# Altium schematic sheet PowerSupply.SchDoc
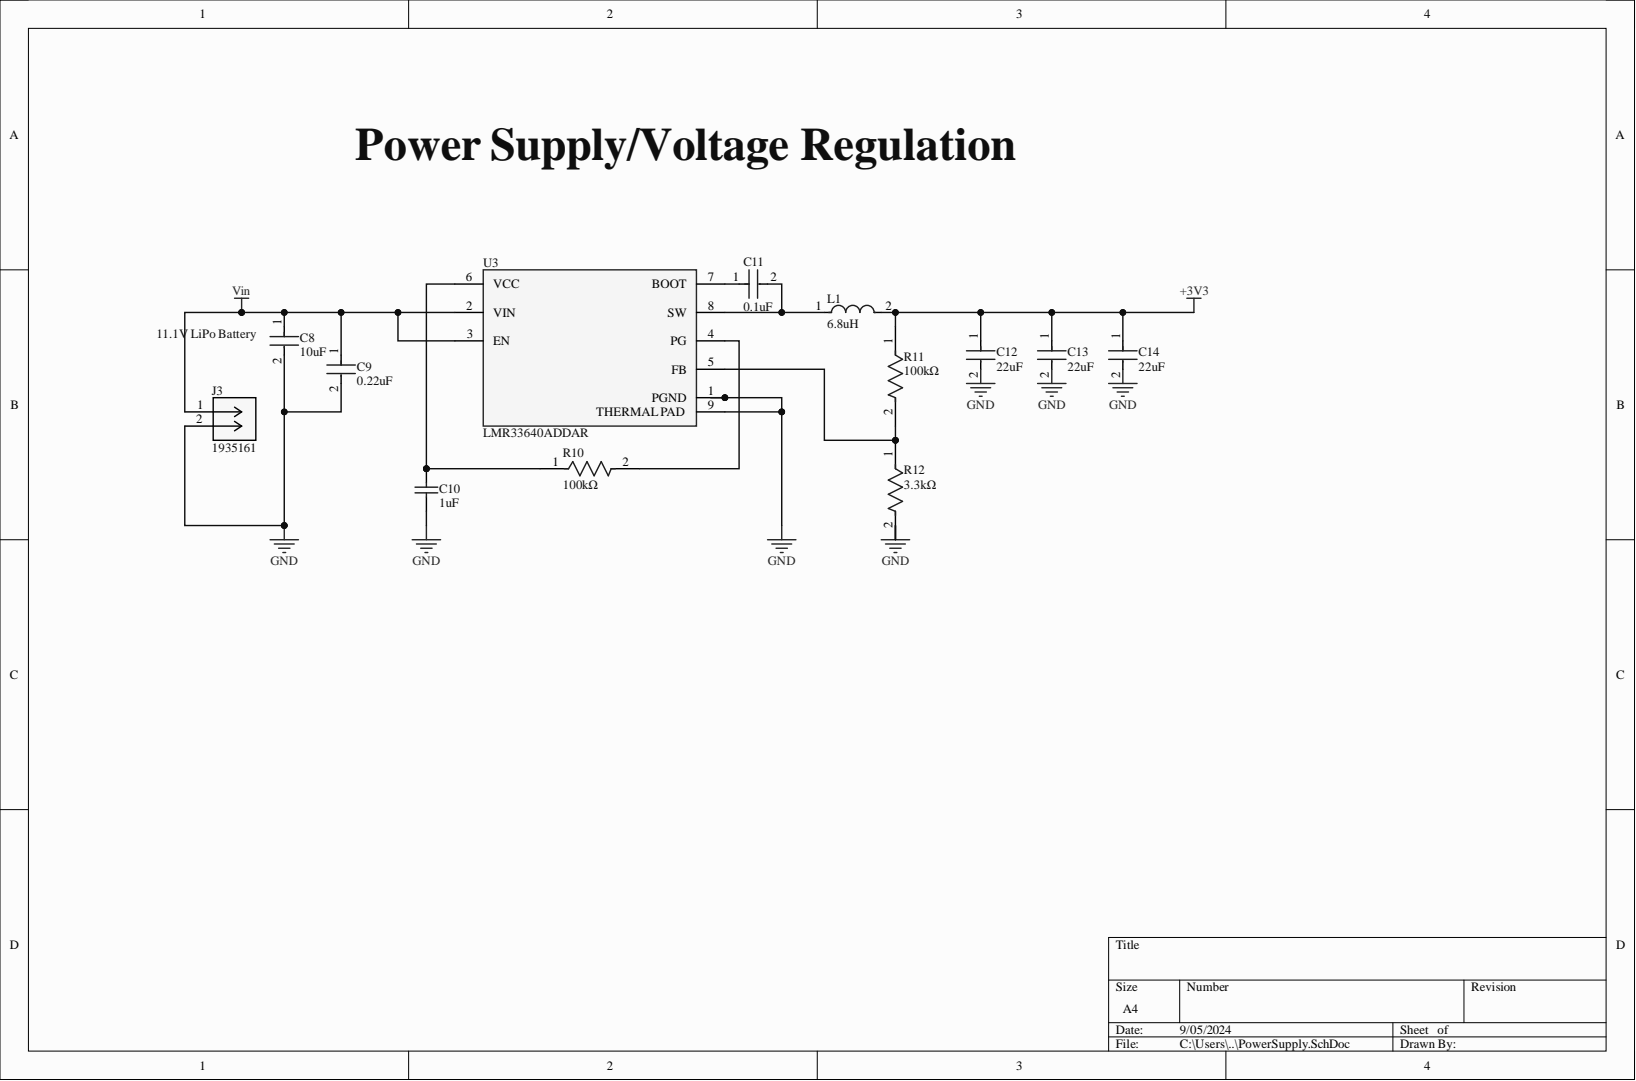
[diagram p0: PowerSupply.SchDoc]

## Parts
C8  10uF  [FP-C0805C-DG-MFG]
C9  0.22uF  [FP-C0603C-CF-MFG]
C10  1uF  [CAPC3216X180X50ML20T25]
C11  0.1uF  [FP-C0805C104M5UACTU-MFG]
C12  22uF  [FP-C3225-250-0_4-IPC_B]
C13  22uF  [FP-C3225-250-0_4-IPC_B]
C14  22uF  [FP-C3225-250-0_4-IPC_B]
J3  1935161  [CONN_1935161_PXC]
L1  6.8uH  [FP-MLZ2012-0_85-IPC_A]
R10  100kΩ  [FP-RMCF0402-IPC_C]
R11  100kΩ  [FP-RMCF0402-IPC_C]
R12  3.3kΩ  [FP-RMCF1210-IPC_A]
U3  LMR33640ADDAR  [FP-DDA0008J-IPC_A]

## Nets
+3V3: C12.1 C13.1 C14.1 L1.2 R11.1
GND: C8.2 C9.2 C10.1 C12.2 C13.2 C14.2 J3.2 R12.2 U3.1(PGND) U3.9(THERMAL_PAD)
Vin: C8.1 C9.1 J3.1 U3.2(VIN) U3.3(EN)
NetC10_2: C10.2 R10.1 U3.6(VCC)
NetC11_1: C11.1 U3.7(BOOT)
NetC11_2: C11.2 L1.1 U3.8(SW)
NetR10_2: R10.2 U3.4(PG)
NetR11_2: R11.2 R12.1 U3.5(FB)

## Library data of the parts
C8 = CMP-2007-03243-2: C0805C106K8PACTU CAP CER 10UF 10V X5R 0805; 3DS Part Number: 220-00001; Manufacturer: Kemet; Material: Ceramic; Temperature Coefficient: 15%; Tolerance: 10%; Automotive: No; Capacitance: 10uF; Category: Cap; Component Kind: Standard; ComponentLink2Description: Datasheet; ComponentLink2URL: https://content.kemet.com/datasheets/KEM_C1006_X5R_SMD.pdf; Device Class L1: Passive Components; Device Class L2: Capacitors; Device Class L3: Ceramic Capacitors; Digikey Description: CAP CER 10UF 10V X5R 0805; Height: 1.4mm; Ipc Land Pattern Name: CAPC200125X125; Lead Free: Yes; Library Name: Altium Content Vault; Manufacturer Part Number: C0805C106K8PACTU; Mouser Description: CAP CER 10UF 10V X5R 0805; Octopart: https://octopart.com/c0805c106k8pactu-kemet-98697; Package: C0805C-DG; RoHS: TRUE; Temperature: -55°C to +85°C; Temperature Characteristic: X5R; Temperature Range High: +85°C; Temperature Range Low: -55°C; Value: 10uF; Voltage: 10V; Voltage Rating: 10V
C9 = CMP-2006-03146-2: C0603C224K3RACTU CAP CER 0.22UF 25V 10% X7R 0603; Manufacturer: KEMET; Automotive Grade: Grade 1; Capacitance: 0.22 μF; Material: Ceramic; Temperature Coefficient: 30ppm/°C; Tolerance: 0.1; Value: 0.22 μF; Automotive: Yes; Category: Cap; Component Kind: Standard; ComponentLink2Description: Datasheet; ComponentLink2URL: https://api.kemet.com/component-edge/download/datasheet/C0603C100J5GACAUTO.pdf; Device Class L1: Passive Components; Device Class L2: Capacitors; Device Class L3: Ceramic Capacitors; Digikey Description: CAP CER 0.22UF 25V 10% X7R 0603; Height: 0.87mm; Lead Free: Yes; Library Name: Altium Content Vault; Manufacturer Part Number: C0603C224K3RACTU; Mouser Description: Multilayer Ceramic Capacitors MLCC - SMD/SMT 25volts 0.22uF X7R 10%; Octopart: https://octopart.com/c0603c224k3ractu-kemet-129141; Package: C0603C-CF; RoHS: TRUE; Temperature: -55°C to +125°C; Temperature Characteristic: X7R; Temperature Range High: +125°C; Temperature Range Low: -55°C; Voltage Rating: 25 V
C10 = CMP-2000-04991-1: C1206C105K3RACTU Chip Capacitor, 1 uF, +/- 10%, 25 V, -55 to 125 degC, 1206 (3216 Metric), RoHS, Tape and Reel; Brand: Kemet; Capacitance - nF: 1000nF; Case Height: 1.6mm; Case Length: 3.2mm; Class: Class1; Dielectric: X7R; Temperature Coefficient / Code: +/-15%; Type: SMDMLCCX7R6.3-250VDCCommericalGrade; Voltage Rating: 25V; Capacitance: 1uF; Case Code - in: 1206; Case Code - mm: 3216; Case Width: 1.6mm; Component Kind: Standard; Datasheet URL: https://ciiva.com/datasheet-click-18194173?src=ciiva&sig=6daff8c4; Datasheet Version: Jul-14; Dimensions: 1.6mmWx3.2mmLx1.6mmH; Factory Pack Quantity: 2000; Library Name: Altium Content Vault; Manufacturer: Kemet; Manufacturer URL: http://www.kemet.com/; Maximum Operating Temperature: +125C; Minimum Operating Temperature: -55C; Operating Temperature Range: -55Cto+125C; Package / Case: 1206(3216metric); Package Description: 2-Pin Surface Mount Device, Body 3.2 x 1.6 mm; Package Reference: 1206; Packaging: Reel; Product: GeneralTypeMLCCs; Product Category: MultilayerCeramicCapacitorsMLCC-SMD/SMT; RoHS: Details; Series: C1206C; Termination Style: SMD/SMT; Tolerance: 10%; Voltage Rating DC: 25V
C11 = CMP-2007-03742-2: C0805C104M5UACTU CAP CER 0.1UF 50V Z5U 0805; Capacitance: 100nF; Dielectric Material: Ceramic; Dissipation Factor: 4%; Tolerance: 20%; Case Code (Imperial): 0805; Case Code (Metric): 2012; Case/Package: 0805; Component Kind: Standard; Construction: Flat; Contact Plating: Tin; Depth: 1.25mm; Dielectric: Z5U; Height: 0.78mm; Lead Free: Lead Free; Lead Pitch: 0.75mm; Length: 2mm; Library Name: Altium Content Vault; Max Operating Temperature: 85°C; Min Operating Temperature: 10°C; Mount: Surface Mount; Number of Pins: 2; Package Quantity: 1; Packaging: Tape and Reel; Radiation Hardening: No; REACH SVHC: No SVHC; RoHS Compliant: Yes; Series: C; Termination: SMD/SMT; Thickness: 0.035inch; Voltage: 50V; Voltage Rating: 50V; Voltage Rating (DC): 50V; Width: 1.2mm
C12-C14 = CMP-2000-06052-2: C3225X7R1C226M250AC Multilayer Ceramic Capacitors 22uF ±20% 16V X7R SMD 1210; Capacitance: 22uF; Case Code (Imperial): 1210; Case Code (Metric): 3225; Case/Package: 1210; Component Kind: Standard; Construction: Flat; Depth: 2.5mm; Dielectric: X7R; Dielectric Material: Ceramic; Height: 2.5mm; Lead Free: Lead Free; Length: 3.2mm; Library Name: Altium Content Vault; Max Operating Temperature: 125°C; Min Operating Temperature: -55°C; Mount: Surface Mount; Number of Pins: 2; Package Quantity: 1; Packaging: Tape and Reel; Radiation Hardening: No; REACH SVHC: No SVHC; Resistor Type: Ceramic; RoHS Compliant: Yes; Series: C; Termination: SMD/SMT; Thickness: 0.11inch; Tolerance: 20%; Voltage Rating: 16V; Voltage Rating (DC): 16V; Weight: 0.000564oz; Width: 0.098inch
J3 = 1935161: Ceramic Capacitor, Multilayer, Ceramic, 16V, 10% +Tol, 10% -Tol, X5R, 15% TC, 10uF, Surface Mount, 0805; Manufacturer: Samsung; Manufacturer Part Number: CL21A106KOQNNNE; Mfr_Name: Phoenix Contact; Multilayer: Yes; Capacitor Type: Ceramic; Case/Package: 0805; Category: Ceramic Capacitors; Component Kind: Standard; ComponentLink1Description: https://datasheet.ciiva.com:443/pdfs/VipMasterIC/IC/SEMS/SEMS-S-A0008520559/SEMS-S-A0008520510-1.pdf?src-supplier=IHS+Markit; ComponentLink1URL: https://datasheet.ciiva.com:443/pdfs/VipMasterIC/IC/SEMS/SEMS-S-A0008520559/SEMS-S-A0008520510-1.pdf?src-supplier=IHS+Markit; ComponentLink2Description: https://datasheet.ciiva.com/15216/getdatasheetpartid-358435-15216234.pdf?src-supplier=Verical; ComponentLink2URL: https://datasheet.ciiva.com/15216/getdatasheetpartid-358435-15216234.pdf?src-supplier=Verical; ComponentLink3Description: https://datasheet.ciiva.com/25798/cl21a106koqnnne-25798133.pdf?src-supplier=Digikey; ComponentLink3URL: https://datasheet.ciiva.com/25798/cl21a106koqnnne-25798133.pdf?src-supplier=Digikey; Dielectric Material: Ceramic; Height: 1.4mm; JESD-609 Code: e3; Length: 2mm; Library Name: 1935161.SchLib; Max Operating Temperature: 85°C; Min Operating Temperature: -55°C; Mounting Technology: Surface Mount; Package Shape: Rectangular; Package Style: SMTMeter; Packaging: Tape and Reel; Pins: 2; Pricing: 1=0.1, 10=0.07, 50=0.0404, 100=0.0313, 500=0.02354, 1000=0.0185 (USD); Rated DC Voltage (URdc): 16V; Reach SVHC Compliant: Yes; RefDes: RefDes; RoHS: Yes; RoHS Compliant: Yes; Stock: 3573508; Supplier: Digikey; Supplier Part Number: 1276-1096-1-ND; Temperature Characteristics Code: X5R; Terminal Finish: Nickel; Termination: Wraparound; Tolerance  (fill in as +-): 10%; Tolerance (fill in as +-): 10%; Type: Type; Value: 10uF; Width: 1.25mm
L1 = CMP-08253-000092-1: MLZ2012N6R8LT000 Shielded Multilayer Inductor 6.8uH ±20% 550mA 325mΩ SMD 0805; Core Material: Ferrite; DC Resistance (DCR): 250mR; Saturation Current: 110mA; Self Resonant Frequency: 60MHz; Test Frequency: 2MHz; Case Code (Imperial): 0805; Case Code (Metric): 2012; Case/Package: 0805; Component Kind: Standard; Current Rating: 550mA; Height - Seated (Max): 0.057inch; Inductance: 6.8uH; Lead Free: Lead Free; Length: 0.079inch; Library Name: Altium Content Vault; Max Operating Temperature: 125°C; Min Operating Temperature: -55°C; Mount: Surface Mount; Package Quantity: 1; Packaging: Tape and Reel; RoHS Compliant: Yes; Shielding: Shielded; Tolerance: 20%; Width: 0.049inch
R10 R11 = CMP-2000-06984-2: RMCF0402FT100K 100kΩ ±1% 0.063W 0402 Thick Film Chip Resistor AEC-Q200 compliant; Max Power Dissipation: 63mW; Power Rating: 62.5mW; Resistance: 100kR; Temperature Coefficient: 100ppm/°C; Case Code (Imperial): 0402; Case Code (Metric): 1005; Case/Package: 0402; Component Kind: Standard; Construction: Rectangular; Contact Plating: Tin; Depth: 0.5mm; Features: Automotive AEC-Q200; Height: 0.016inch; Lead Free: Lead Free; Length: 1mm; Library Name: Altium Content Vault; Max Operating Temperature: 155°C; Military Standard: Not; Min Operating Temperature: -55°C; Mount: Surface Mount; Number of Terminations: 2; Packaging: Tape and Reel; Radiation Hardening: No; Resistor Type: Thick Film; RoHS Compliant: Yes; Series: RMCF; Size Code: 0402; Tolerance: 1%; Width: 0.02inch
R12 = CMP-26527-001928-1: RMCF1210JT3K30 3.3kΩ ±5% 0.5W 1210 Thick Film Chip Resistor AEC-Q200 compliant; Max Power Dissipation: 333mW; Resistance: 3.3kR; Temperature Coefficient: 200ppm/°C; Case/Package: 1210; Component Kind: Standard; Contact Plating: Tin; Features: Automotive AEC-Q200; Height: 0.028inch; Lead Free: Lead Free; Length: 0.126inch; Library Name: Altium Content Vault; Max Operating Temperature: 155°C; Min Operating Temperature: -55°C; Mount: Surface Mount; Number of Terminations: 2; Packaging: Tape and Reel; Radiation Hardening: No; Resistor Type: Thick Film; RoHS Compliant: Yes; Series: RMCF; Tolerance: 5%; Width: 0.098inch
U3 = CMP-04918-000399-1: 36V 4A 400KHZ REGULATOR; Component Kind: Standard; Library Name: Altium Content Vault
